# Altium schematic sheet LH-Cloud_EC20.SchDoc(LH-Cloud_EC20)
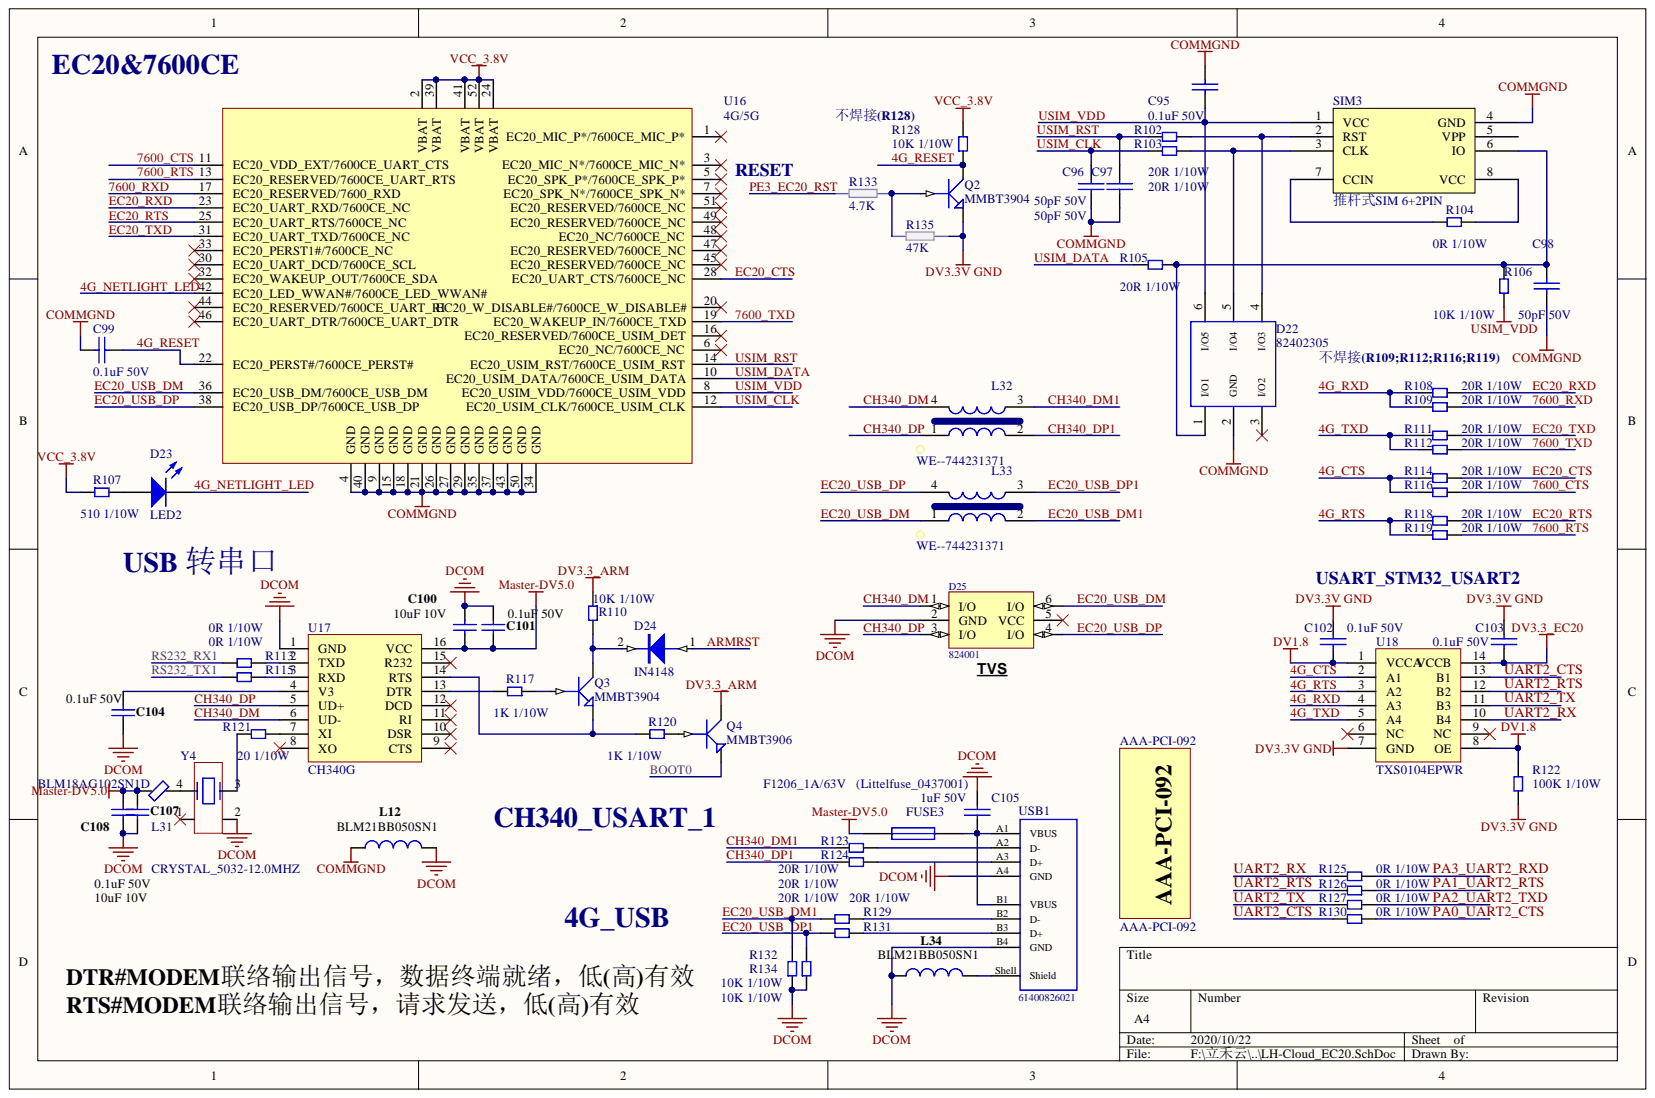
[diagram p0: LH-Cloud_EC20.SchDoc]

## Parts
AAA-PCI-092  AAA-PCI-092
C95  0.1uF 50V  [C0603]
C96  50pF 50V  [C0603]
C97  50pF 50V  [C0603]
C98  50pF 50V  [C0603]
C99  0.1uF 50V  [C0603]
C100  10uF 10V  [C0603_106/50V]
C101  0.1uF 50V  [C0603_104/50V]
C102  0.1uF 50V  [C0603]
C103  0.1uF 50V  [C0603]
C104  0.1uF 50V  [C0603_104/50V]
C105  1uF 50V  [C0603_105/50V]
C107  0.1uF 50V  [C0603_104/50V]
C108  10uF 10V  [C0603_106/50V]
D22  82402305  [WE-TVS_SOT23-6L]
D23  LED2  [LED_0805]
D24  IN4148  [SOD123_IN4148]
D25  824001  [SOT23-6_WE-824001]
FUSE3  F1206_1A/63V   (Littelfuse_0437001)  [1206]
L12  BLM21BB050SN1  [0805_BLM21BB050SN1]
L31  BLM18AG102SN1D  [0603_BLM18AG102SN1D]
L32  WE--744231371  [CNSW-0805]
L33  WE--744231371  [CNSW-0805]
L34  BLM21BB050SN1  [0805_BLM21BB050SN1]
Q2  MMBT3904  [SOT-23_MMBT3904]
Q3  MMBT3904  [SOT-23_MMBT3904]
Q4  MMBT3906  [SOT-23_MMBT3906]
R102  20R 1/10W  [RES0603]
R103  20R 1/10W  [RES0603]
R104  0R 1/10W  [res0603_200]
R105  20R 1/10W  [RES0603]
R106  10K 1/10W  [RES0603]
R107  510 1/10W  [RES0603]
R108  20R 1/10W  [RES0603]
R109  20R 1/10W  [RES0603]
R110  10K 1/10W  [RES0603]
R111  20R 1/10W  [RES0603]
R112  20R 1/10W  [RES0603]
R113  0R 1/10W  [res0603_200]
R114  20R 1/10W  [RES0603]
R115  0R 1/10W  [res0603_200]
R116  20R 1/10W  [RES0603]
R117  1K 1/10W  [RES0603]
R118  20R 1/10W  [RES0603]
R119  20R 1/10W  [RES0603]
R120  1K 1/10W  [RES0603]
R121  20 1/10W  [res0603_200]
R122  100K 1/10W  [RES0603_100]
R123  20R 1/10W  [RES0603]
R124  20R 1/10W  [RES0603]
R125  0R 1/10W  [res0603_200]
R126  0R 1/10W  [res0603_200]
R127  0R 1/10W  [res0603_200]
R128  10K 1/10W  [RES0603]
R129  20R 1/10W  [RES0603]
R130  0R 1/10W  [res0603_200]
R131  20R 1/10W  [RES0603]
R132  10K 1/10W  [RES0603]
R133  4.7K  [RES0603]
R134  10K 1/10W  [RES0603]
R135  47K  [RES0603_473]
SIM3  推杆式SIM 6+2PIN  [SIMCARD8]
U16  4G/5G  [3G/4G模块_PCI]
U17  CH340G  [SOP-16]
U18  TXS0104EPWR  [TSSOP-14]
USB1  61400826021  [61400826021]
Y4  CRYSTAL_5032-12.0MHZ  [CR-5032_24MHZ]

## Nets
COMMGND: C95.1 C96.2 C97.2 C98.2 C99.1 D22.2(GND) L12.1 SIM3.4(GND) U16.4(GND) U16.9(GND) U16.15(GND) U16.18(GND) U16.21(GND) U16.26(GND) U16.27(GND) U16.29(GND) U16.34(GND) U16.35(GND) U16.37(GND) U16.40(GND) U16.43(GND) U16.50(GND)
DCOM: C100.1 C101.1 C104.2 C105.2 C107.2 C108.2 D25.2(GND) L12.2 L34.1 R132.1 R134.1 U17.1(GND) USB1.A4(GND) USB1.B4(GND) Y4.2
DV1.8: C102.2 R122.1 U18.1(VCCA) U18.8(OE)
DV3.3_ARM: Q4.3 R110.1
DV3.3_EC20: C103.2 U18.14(VCCB)
DV3.3V GND: C102.1 C103.1 Q2.2 R122.2 R135.1 U18.7(GND)
Master-DV5.0: C100.2 C101.2 C107.1 C108.1 FUSE3.1 L31.1 U17.16(VCC)
VCC_3.8V: R107.1 R128.2 U16.2(VBAT) U16.24(VBAT) U16.39(VBAT) U16.41(VBAT) U16.52(VBAT)
4G_CTS: R114.2 R116.2 U18.2(A1)
4G_NETLIGHT_LED: D23.2 U16.42(EC20_LED_WWAN#/7600CE_LED_WWAN#)
4G_RESET: C99.2 Q2.3 R128.1 U16.22(EC20_PERST#/7600CE_PERST#)
4G_RTS: R118.2 R119.2 U18.3(A2)
4G_RXD: R108.2 R109.2 U18.4(A3)
4G_TXD: R111.2 R112.2 U18.5(A4)
7600_CTS: R116.1 U16.11(EC20_VDD_EXT/7600CE_UART_CTS)
7600_RTS: R119.1 U16.13(EC20_RESERVED/7600CE_UART_RTS)
7600_RXD: R109.1 U16.17(EC20_RESERVED/7600_RXD)
7600_TXD: R112.1 U16.19(EC20_WAKEUP_IN/7600CE_TXD)
ARMRST: D24.1
BOOT0: Q4.2
CH340_DM: D25.1(I/O) L32.4 U17.6(UD-)
CH340_DM1: L32.3 R123.2
CH340_DP: D25.3(I/O) L32.1 U17.5(UD+)
CH340_DP1: L32.2 R124.2
EC20_CTS: R114.1 U16.28(EC20_UART_CTS/7600CE_NC)
EC20_RTS: R118.1 U16.25(EC20_UART_RTS/7600CE_NC)
EC20_RXD: R108.1 U16.23(EC20_UART_RXD/7600CE_NC)
EC20_TXD: R111.1 U16.31(EC20_UART_TXD/7600CE_NC)
EC20_USB_DM: D25.6(I/O) L33.1 U16.36(EC20_USB_DM/7600CE_USB_DM)
EC20_USB_DM1: L33.2 R129.2 R132.2
EC20_USB_DP: D25.4(I/O) L33.4 U16.38(EC20_USB_DP/7600CE_USB_DP)
EC20_USB_DP1: L33.3 R131.2 R134.2
PA0_UART2_CTS: R130.1
PA1_UART2_RTS: R126.1
PA2_UART2_TXD: R127.1
PA3_UART2_RXD: R125.1
PE3_EC20_RST: R133.2
RS232_RX1: R113.2
RS232_TX1: R115.2
UART2_CTS: R130.2 U18.13(B1)
UART2_RTS: R126.2 U18.12(B2)
UART2_RX: R125.2 U18.10(B4)
UART2_TX: R127.2 U18.11(B3)
USIM_CLK: C96.1 R103.2 U16.12(EC20_USIM_CLK/7600CE_USIM_CLK)
USIM_DATA: R105.2 U16.10(EC20_USIM_DATA/7600CE_USIM_DATA)
USIM_RST: C97.1 R102.2 U16.14(EC20_USIM_RST/7600CE_USIM_RST)
USIM_VDD: C95.2 D22.6(I/O5) R106.1 SIM3.1(VCC) U16.8(EC20_USIM_VDD/7600CE_USIM_VDD)
NetC98_1: C98.1 D22.1(I/O1) R105.1 R106.2 SIM3.6(IO)
NetC104_1: C104.1 U17.4(V3)
NetC105_1: C105.1 FUSE3.2 USB1.A1(VBUS) USB1.B1(VBUS)
NetD22_4: D22.4(I/O3) R102.1 SIM3.2(RST)
NetD22_5: D22.5(I/O4) R103.1 SIM3.3(CLK)
NetD23_1: D23.1 R107.2
NetD24_2: D24.2 Q3.3 R110.2
NetL31_2: L31.2 Y4.4
NetL34_2: L34.2 USB1.Shell(Shield)
NetQ2_1: Q2.1 R133.1 R135.2
NetQ3_1: Q3.1 R117.1
NetQ3_2: Q3.2 R120.2 U17.14(RTS)
NetQ4_1: Q4.1 R120.1
NetR104_1: R104.1 SIM3.8(VCC)
NetR104_2: R104.2 SIM3.7(CCIN)
NetR113_1: R113.1 U17.2(TXD)
NetR115_1: R115.1 U17.3(RXD)
NetR117_2: R117.2 U17.13(DTR)
NetR121_1: R121.1 U17.7(XI)
NetR121_2: R121.2 Y4.3
NetR123_1: R123.1 USB1.A2(D-)
NetR124_1: R124.1 USB1.A3(D+)
NetR129_1: R129.1 USB1.B2(D-)
NetR131_1: R131.1 USB1.B3(D+)

Not connected: D22 3(I/O2); D25 5(VCC); SIM3 5(VPP); U16 1(EC20_MIC_P*/7600CE_MIC_P*) 3(EC20_MIC_N*/7600CE_MIC_N*) 5(EC20_SPK_P*/7600CE_SPK_P*) 6(EC20_NC/7600CE_NC) 7(EC20_SPK_N*/7600CE_SPK_N*) 16(EC20_RESERVED/7600CE_USIM_DET) 20(EC20_W_DISABLE#/7600CE_W_DISABLE#) 30(EC20_UART_DCD/7600CE_SCL) 32(EC20_WAKEUP_OUT/7600CE_SDA) 33(EC20_PERST1#/7600CE_NC) 44(EC20_RESERVED/7600CE_UART_RI) 45(EC20_RESERVED/7600CE_NC) 46(EC20_UART_DTR/7600CE_UART_DTR) 47(EC20_RESERVED/7600CE_NC) 48(EC20_NC/7600CE_NC) 49(EC20_RESERVED/7600CE_NC) 51(EC20_RESERVED/7600CE_NC); U17 8(XO) 9(CTS) 10(DSR) 11(RI) 12(DCD) 15(R232); U18 6(NC) 9(NC); Y4 1

## Library data of the parts
AAA-PCI-092 = CON14_1: 连得紧密PCI插槽; Library Name: 2015.10.1.SCHLIB; Component Kind: Standard
C95-C99 C102 C103 C105 = CAP: 'SAMSUNG  MULTILAYER  CERAMIC 5%  0603 X7R; Component Kind: Standard
C100 C108 = D-101-0087: Capacitor, Ceramic, 10uF 10% 10V 0603  X5R; Polarity: Non-Polarized; Tolerance: 10 %; Technology: None; Status: Unreleased; Rated Voltage: 10 V; Mounting Technology: Surface Mount; Library Name: AltiumDesignLibrary.DesignLib; Dielectric: X5R; Component Kind: Standard; Category: Capacitor; Capacitance: 10 uF
C101 C104 C107 = D-101-0080: Capacitor, Ceramic, 0.1uF 10% 16V 0603  X7R; Polarity: Non-Polarized; Tolerance: 10 %; Technology: Ceramic; Status: Production; Rated Voltage: 16 V; Mounting Technology: Surface Mount; Library Name: AltiumDesignLibrary.DesignLib; Dielectric: X7R; Component Kind: Standard; Category: Capacitor; Capacitance: 0.1 uF
D22 = 82402305: WE-TVS TVS Diode - Standard Series, SOT23-6L, 5Channel, 5Vdc; Manufacturer: Wurth Elektronik; Reverse Peak Pulse Current: 6A; Reverse Channel Leakage Current: 2.5uA; Reverse Breakdown Voltage min.: 6V; DC Operating Voltage: 5V; Channel Input Capacitance: 13pF; Channel: 5; Size: SOT23-6L; Published Date: April 2020; Packaging: Tape and Reel; Operating Temperature: -55 to +85degC; Mounting Technology: SMT; Manufacturer Part Number: 82402305; Library Name: WE-TVS.SchLib; ComponentLink2URL: https://www.we-online.com/catalog/datasheet/82402305.pdf; ComponentLink2Description: Datasheet; ComponentLink1URL: http://www.we-online.de; ComponentLink1Description: Manufacturer; Component Kind: Standard
D23 = LED2: Typical  GREEN,  AMBER GaAs LED; Simulation: LED2; Published: 8-Jun-2000; PackageVersion: Feb-2002; PackageReference: 3.2X1.6X1.1; PackageDescription: SM LED; 2 Flat Leads; Library Name: Miscellaneous Devices.IntLib; LatestRevisionNote: Re-released for DXP Platform.; LatestRevisionDate: 17-Jul-2002; Component Kind: Standard; Publisher: Altium Limited
D24 = DIODE: 1N4148WS-7; Component Kind: Standard
D25 = SP0504SHTG: TVS Diode Arrays WE-TVS; AEMS Code: 1300330; Library Name: 2015.10.1.SCHLIB; Component Kind: Standard
FUSE3 = FUSE1: Littelfuse Surface Mount Fuses 437Series-Hight Current 1206 Fast-ACT; Component Kind: Standard
L12 L34 = D-102-0002: Inductor, Ferrite Bead 1K@100MHz 300mA 0805 (2012) BLM21BB050SN1; Inductance: nH; Status: Production; Mounting Technology: Surface Mount; Max Input Current: 300 mA; Library Name: AltiumDesignLibrary.DesignLib; Component Kind: Standard; Category: Inductor
L31 = FERRITE BEAD: EMIFIL (Inductor type) Chip Ferrite Bead BLM18A Series (0603 Size); Component Kind: Standard
L32 L33 = WE-CNSW: SMD Common Mode Line Filter  Z=2X370Ohm RDC=370Ohm I=280mA WE--744231371; Library Name: 2015.10.1.SCHLIB; Component Kind: Standard
Q2 Q3 = NPN1: NPN Transistor; Component Kind: Standard
Q4 = PNP: PNP Transistor; Component Kind: Standard; Library Name: LH-Cloud-Board_V1.0.SCHLIB
R102-R121 R123-R132 R134 = RES2: YAGEO Chip Res 1/10W 1%; Component Kind: Standard
R122 = RES2: Component Kind: Standard
R133 R135 = Res2: Resistor; Simulation: RESISTOR; Signal Integrity: Res; Published: 8-Jun-2000; PackageReference: AXIAL-0.4; Library Name: 3DGeneral.SCHLIB; LatestRevisionNote: Re-released for DXP Platform.; LatestRevisionDate: 17-Jul-2002; Component Kind: Standard; Publisher: Altium Limited
SIM3 = SIM-抽屉式: 连得精密推杆SIM  6+2PIN; Component Kind: Standard
U16 = EC20&7600CE_MINI_PCI: EC20CEFAG-MINIPCIE-C(上海移远通信技术股份有限公司); Component Kind: Standard; Library Name: Comm-Board_V1.2.SCHLIB
U17 = CH340G: USB to UART Interface; Component Kind: Standard; Library Name: 常用芯片.SchLib
U18 = TXS0104: 4-BIT BIDIRECTIONAL VOLTAGE-LEVEL TRANSLATOR FOR OPEN-DRAIN AND PUSH-PULL APPLICATIONS; Component Kind: Standard; Library Name: LH-Cloud-Board_V1.0.SCHLIB
USB1 = 61400826021: USB 2.0 Type A, Receptacle, Horizontal, THT, Dual Stacked; Manufacturer: Wurth Elektronik; Working Voltage: 30VAC; Rated Current: 1.5A; Pin Number: 8; Interface Type: Type A; Type: Horizontal; Published Date: August 2020; Packaging: Tray; Operating Temperature: -40 to +105degC; Mounting Technology: THT; Manufacturer Part Number: 61400826021; Library Name: WR-USB_USB 2.0.SchLib; Gender: Receptacle; ComponentLink2URL: https://katalog.we-online.com/em/datasheet/61400826021.pdf; ComponentLink2Description: Datasheet; ComponentLink1URL: http://www.we-online.de; ComponentLink1Description: Manufacturer; Component Kind: Standard; Application: USB 2.0
Y4 = CRYSTAL_5032: SG5032CAN24.0MHZTJGA; Library Name: 2017.4.24 MainBoard.SchLib; Component Kind: Standard
